# Altium schematic sheet sensors.SchDoc
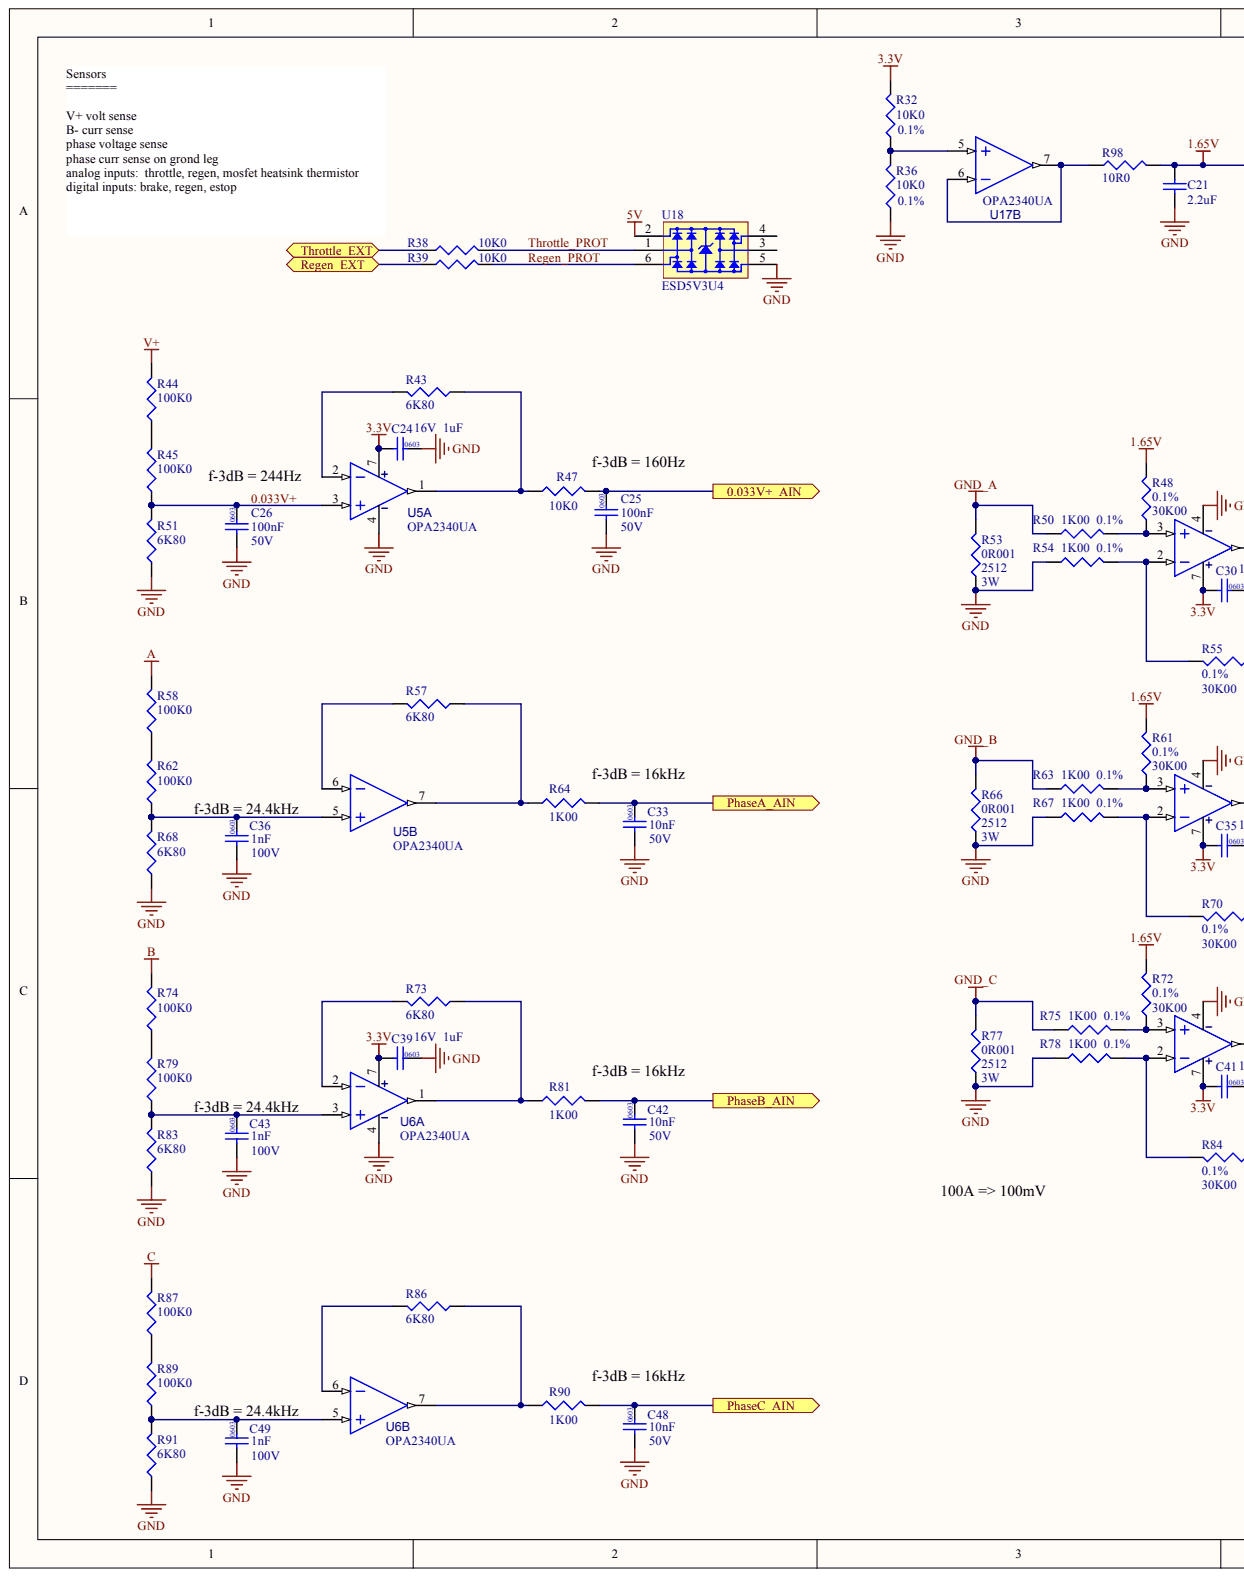
[diagram p0: sensors.SchDoc, left]
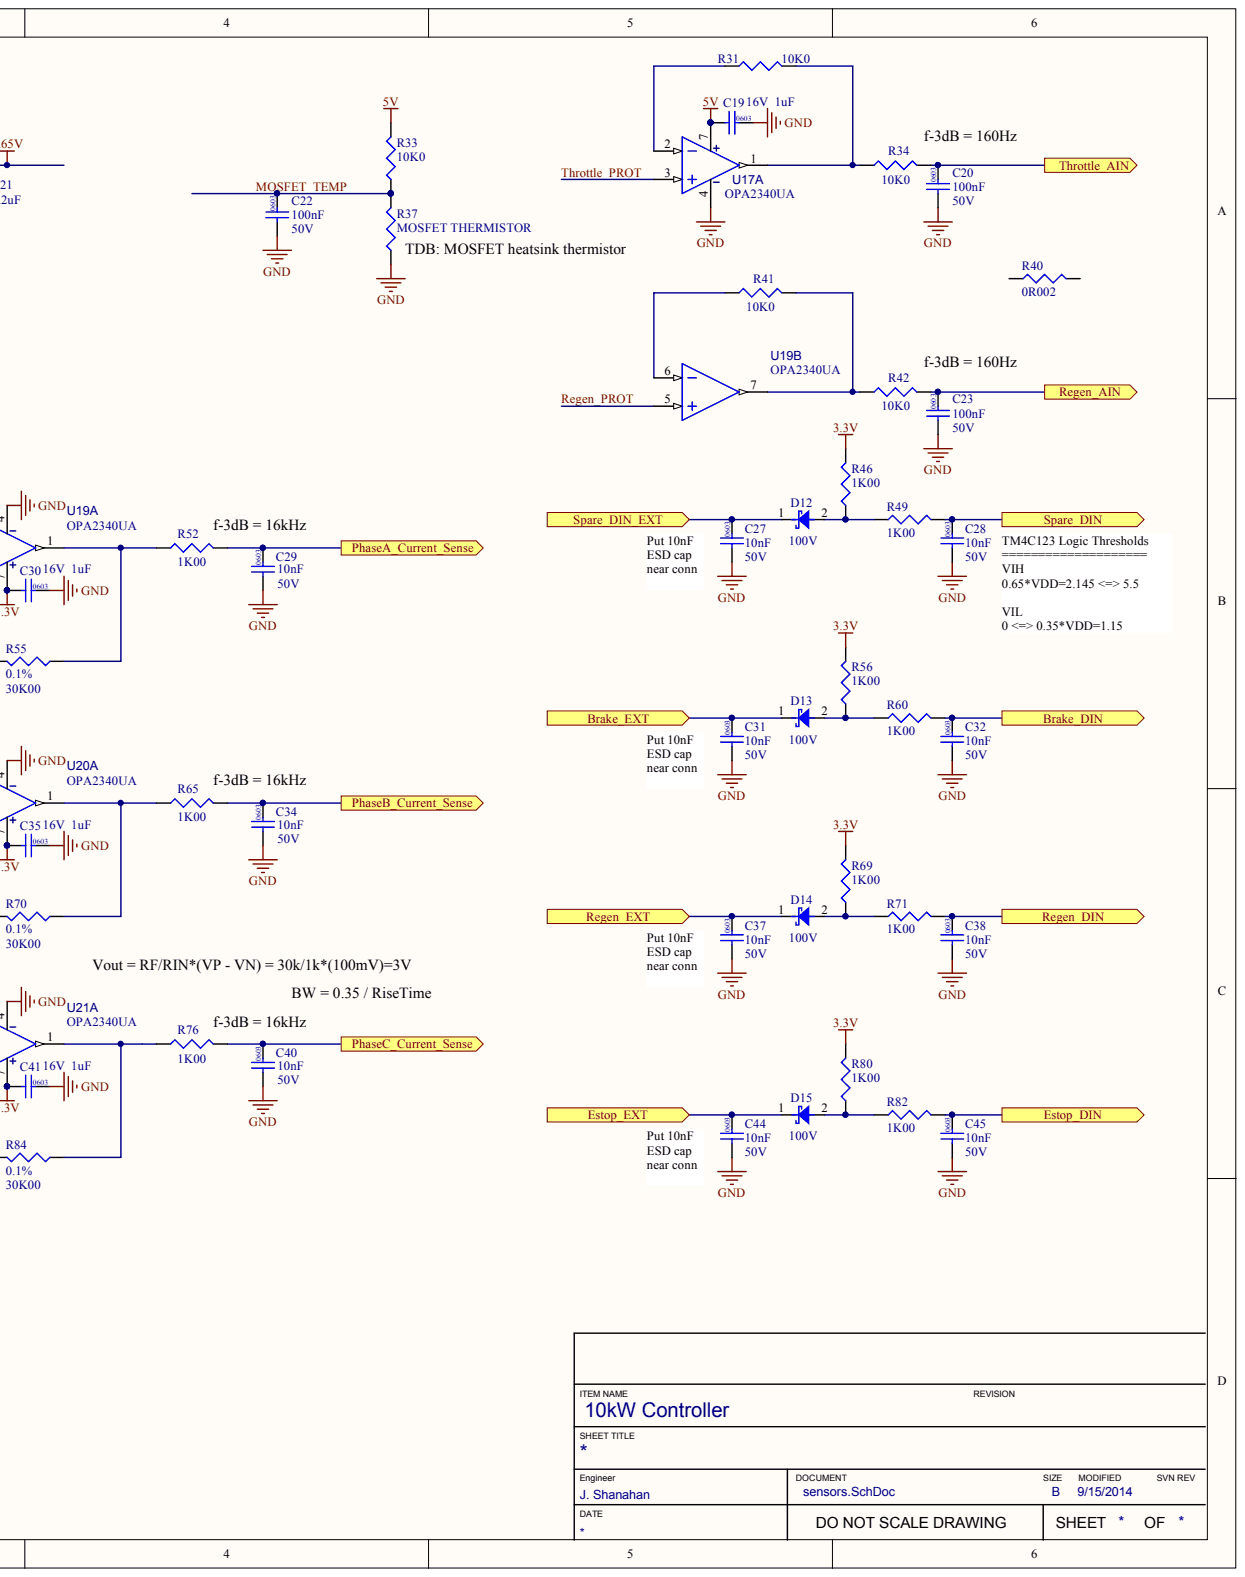
[diagram p1: sensors.SchDoc, right]

## Parts
C19  voltage: 16V  (hidden comment: 1uF)
C20  voltage: 50V  (hidden comment: 100nF)
C21  value 2.2uF  (hidden comment: 2.2UF,10V,X7R(0603))
C22  voltage: 50V  (hidden comment: 100nF)
C23  voltage: 50V  (hidden comment: 100nF)
C24  voltage: 16V  (hidden comment: 1uF)
C25  voltage: 50V  (hidden comment: 100nF)
C26  voltage: 50V  (hidden comment: 100nF)
C27  value 10nF  Voltage: 50V  (hidden comment: 2005187)
C28  Voltage: 50V  (hidden comment: 10nF)
C29  Voltage: 50V  (hidden comment: 10nF)
C30  voltage: 16V  (hidden comment: 1uF)
C31  value 10nF  Voltage: 50V  (hidden comment: 2005187)
C32  Voltage: 50V  (hidden comment: 10nF)
C33  Voltage: 50V  (hidden comment: 10nF)
C34  Voltage: 50V  (hidden comment: 10nF)
C35  voltage: 16V  (hidden comment: 1uF)
C36  Voltage: 100V  (hidden comment: 1nF)
C37  value 10nF  Voltage: 50V  (hidden comment: 2005187)
C38  Voltage: 50V  (hidden comment: 10nF)
C39  voltage: 16V  (hidden comment: 1uF)
C40  Voltage: 50V  (hidden comment: 10nF)
C41  voltage: 16V  (hidden comment: 1uF)
C42  Voltage: 50V  (hidden comment: 10nF)
C43  Voltage: 100V  (hidden comment: 1nF)
C44  value 10nF  Voltage: 50V  (hidden comment: 2005187)
C45  Voltage: 50V  (hidden comment: 10nF)
C48  Voltage: 50V  (hidden comment: 10nF)
C49  Voltage: 100V  (hidden comment: 1nF)
D12  voltage: 100V  (hidden comment: 1046968-00-A)
D13  voltage: 100V  (hidden comment: 1046968-00-A)
D14  voltage: 100V  (hidden comment: 1046968-00-A)
D15  voltage: 100V  (hidden comment: 1046968-00-A)
R31  10K0
R32  value 10K0  Tolerance: 0.1%  (hidden comment: 10K0,0.10W,0.1%(0603))
R33  10K0
R34  10K0
R36  value 10K0  Tolerance: 0.1%  (hidden comment: 10K0,0.10W,0.1%(0603))
R37  MOSFET THERMISTOR
R38  10K0
R39  10K0
R40  value 0R002  (hidden comment: 0R002,1.0W,1%(2512))
R41  10K0
R42  10K0
R43  value 6K80  (hidden comment: CRCW06036K80FKTA)
R44  100K0
R45  100K0
R46  1K00
R47  10K0
R48  value 30K00  Tolerance: 0.1%  (hidden comment: RG1608P-303-B-T5)
R49  value 1K00  (hidden comment: 2006259)
R50  value 1K00  Tolerance: 0.1%  (hidden comment: TNPW06031K00BEEA)
R51  value 6K80  (hidden comment: CRCW06036K80FKTA)
R52  1K00
R53  value 0R001  Package: 2512  Power: 3W  (hidden comment: 0R001,1.0W,1%(2512))
R54  value 1K00  Tolerance: 0.1%  (hidden comment: TNPW06031K00BEEA)
R55  value 30K00  Tolerance: 0.1%  (hidden comment: RG1608P-303-B-T5)
R56  1K00
R57  value 6K80  (hidden comment: CRCW06036K80FKTA)
R58  100K0
R60  value 1K00  (hidden comment: 2006259)
R61  value 30K00  Tolerance: 0.1%  (hidden comment: RG1608P-303-B-T5)
R62  100K0
R63  value 1K00  Tolerance: 0.1%  (hidden comment: TNPW06031K00BEEA)
R64  1K00
R65  1K00
R66  value 0R001  Package: 2512  Power: 3W  (hidden comment: 0R001,1.0W,1%(2512))
R67  value 1K00  Tolerance: 0.1%  (hidden comment: TNPW06031K00BEEA)
R68  value 6K80  (hidden comment: CRCW06036K80FKTA)
R69  1K00
R70  value 30K00  Tolerance: 0.1%  (hidden comment: RG1608P-303-B-T5)
R71  value 1K00  (hidden comment: 2006259)
R72  value 30K00  Tolerance: 0.1%  (hidden comment: RG1608P-303-B-T5)
R73  value 6K80  (hidden comment: CRCW06036K80FKTA)
R74  100K0
R75  value 1K00  Tolerance: 0.1%  (hidden comment: TNPW06031K00BEEA)
R76  1K00
R77  value 0R001  Package: 2512  Power: 3W  (hidden comment: 0R001,1.0W,1%(2512))
R78  value 1K00  Tolerance: 0.1%  (hidden comment: TNPW06031K00BEEA)
R79  100K0
R80  1K00
R81  1K00
R82  value 1K00  (hidden comment: 2006259)
R83  value 6K80  (hidden comment: CRCW06036K80FKTA)
R84  value 30K00  Tolerance: 0.1%  (hidden comment: RG1608P-303-B-T5)
R86  value 6K80  (hidden comment: CRCW06036K80FKTA)
R87  100K0
R89  100K0
R90  1K00
R91  value 6K80  (hidden comment: CRCW06036K80FKTA)
R98  value 10R0  (hidden comment: CRCW060310R0FKEA)
U5  OPA2340UA  (parts A,B)
U6  OPA2340UA  (parts A,B)
U17  OPA2340UA  (parts A,B)
U18  ESD5V3U4
U19  OPA2340UA  (parts A,B)
U20  OPA2340UA  (part A)
U21  OPA2340UA  (part A)

## Ports
0.033V+_AIN, BRAKE_DIN, BRAKE_EXT, ESTOP_DIN, ESTOP_EXT, PHASEA_AIN, PHASEA_CURRENT_SENSE, PHASEB_AIN, PHASEB_CURRENT_SENSE, PHASEC_AIN, PHASEC_CURRENT_SENSE, REGEN_AIN, REGEN_DIN, REGEN_EXT, SPARE_DIN, SPARE_DIN_EXT, THROTTLE_AIN, THROTTLE_EXT

## Nets
1.65V: C21.2 R48.2 R61.2 R72.2 R98.2
3.3V: C24.2 C30.2 C35.2 C39.2 C41.2 R32.2 R46.2 R56.2 R69.2 R80.2 U5.7 U6.7 U19.7 U20.7 U21.7
5V: C19.2 R33.2 U17.7 U18.2
A: R58.2
B: R74.2
C: R87.2
GND: C19.1 C20.2 C21.1 C22.2 C23.2 C24.1 C25.2 C26.2 C27.2 C28.2 C29.2 C30.1 C31.2 C32.2 C33.2 C34.2 C35.1 C36.2 C37.2 C38.2 C39.1 C40.2 C41.1 C42.2 C43.2 C44.2 C45.2 C48.2 C49.2 R36.1 R37.1 R51.1 R53.1 R54.1 R66.1 R67.1 R68.1 R77.1 R78.1 R83.1 R91.1 U5.4 U6.4 U17.4 U18.5 U19.4 U20.4 U21.4
GND_A: R50.1 R53.2
GND_B: R63.1 R66.2
GND_C: R75.1 R77.2
V+: R44.2
0.033V+: C26.1 R45.1 R51.2 U5.3
0.033V+_AIN: C25.1 R47.2 ; port 0.033V+_AIN
MOSFET_TEMP: C22.1 R33.1 R37.2
REGEN_PROT: R39.2 U18.6 U19.5
THROTTLE_PROT: R38.2 U17.3 U18.1
NetC20_1: C20.1 R34.2 ; port THROTTLE_AIN
NetC23_1: C23.1 R42.2 ; port REGEN_AIN
NetC27_1: C27.1 D12.1 ; port SPARE_DIN_EXT
NetC28_1: C28.1 R49.2 ; port SPARE_DIN
NetC29_1: C29.1 R52.2 ; port PHASEA_CURRENT_SENSE
NetC31_1: C31.1 D13.1 ; port BRAKE_EXT
NetC32_1: C32.1 R60.2 ; port BRAKE_DIN
NetC33_1: C33.1 R64.2 ; port PHASEA_AIN
NetC34_1: C34.1 R65.2 ; port PHASEB_CURRENT_SENSE
NetC36_1: C36.1 R62.1 R68.2 U5.5
NetC37_1: C37.1 D14.1 R39.1 ; port REGEN_EXT
NetC38_1: C38.1 R71.2 ; port REGEN_DIN
NetC40_1: C40.1 R76.2 ; port PHASEC_CURRENT_SENSE
NetC42_1: C42.1 R81.2 ; port PHASEB_AIN
NetC43_1: C43.1 R79.1 R83.2 U6.3
NetC44_1: C44.1 D15.1 ; port ESTOP_EXT
NetC45_1: C45.1 R82.2 ; port ESTOP_DIN
NetC48_1: C48.1 R90.2 ; port PHASEC_AIN
NetC49_1: C49.1 R89.1 R91.2 U6.5
NetD12_2: D12.2 R46.1 R49.1
NetD13_2: D13.2 R56.1 R60.1
NetD14_2: D14.2 R69.1 R71.1
NetD15_2: D15.2 R80.1 R82.1
NetR31_1: R31.1 U17.2
NetR31_2: R31.2 R34.1 U17.1
NetR32_1: R32.1 R36.2 U17.5
NetR38_1: R38.1 ; port THROTTLE_EXT
NetR41_1: R41.1 U19.6
NetR41_2: R41.2 R42.1 U19.7
NetR43_1: R43.1 R47.1 U5.1
NetR43_2: R43.2 U5.2
NetR44_1: R44.1 R45.2
NetR48_1: R48.1 R50.2 U19.3
NetR52_1: R52.1 R55.1 U19.1
NetR54_2: R54.2 R55.2 U19.2
NetR57_1: R57.1 R64.1 U5.7
NetR57_2: R57.2 U5.6
NetR58_1: R58.1 R62.2
NetR61_1: R61.1 R63.2 U20.3
NetR65_1: R65.1 R70.1 U20.1
NetR67_2: R67.2 R70.2 U20.2
NetR72_1: R72.1 R75.2 U21.3
NetR73_1: R73.1 R81.1 U6.1
NetR73_2: R73.2 U6.2
NetR74_1: R74.1 R79.2
NetR76_1: R76.1 R84.1 U21.1
NetR78_2: R78.2 R84.2 U21.2
NetR86_1: R86.1 R90.1 U6.7
NetR86_2: R86.2 U6.6
NetR87_1: R87.1 R89.2
NetR98_1: R98.1 U17.6 U17.7

Not connected: R40 1 2; U18 3 4

## Library data of the parts
C19 C24 C30 C35 C39 C41 = (no symbol name): tolerance: 10%; MFG1_PN: GCM188R71C105KA64D; MFG1: Murata; Load: NL; Detail Description: 1uF Capacitor, 16V, X7R, 10%, in 0603 package, Automotive Grade.; TPN: 01-006170-00; Enovia: 2005400; SAP: 2005400; Value: 1uF
C20 C22 C23 C25 C26 = (no symbol name): MFG1_PN: GCM188R71H104KA57D; MFG1: Murata; Value: 100nF
C21 = (no symbol name): tolerance: 10%; TPN: 01-004842-00; LEVEL: 1; MFG1: MURATA; MFG1_PN: GRM188R71A225KE15D; SAP: 2007798; Revision: July-2002: Re-released for DXP Platform.; voltage: 10V; RoHS: YES; TYPE: X7R; Supplier 1: Digi-Key; Supplier 2: Digi-Key; Supplier Part Number 1: 490-4520-6-ND; Supplier Part Number 2: 490-4520-2-ND
C27 C29 C31 C33 C34 C37 C40 C42 C44 C48 = (no symbol name): Tolerance: 10%; MFG1_PN: GCM188R71H103KA37D; MFG1: Murata; Load: NL; Detail Description: 10nF Capacitor, 50V, X7R, 10%, in 0603 package, Automotive Grade.; TPN: 01-006036-00
  C27 C31 C37 C44: Enovia: 2005187; SAP: 2005187
  C29 C33 C34 C40 C42 C48: Value: 10nF
C28 C32 C38 C45 = (no symbol name): Tolerance: 10%; MFG1_PN: GCM188R71H103KA37D; MFG1: Murata; Load: NL; Detail Description: 10nF Capacitor, 50V, X7R, 10%, in 0603 package, Automotive Grade.; TPN: 01-006036-00; Enovia: 2005187; Value: 10nF; SAP: 2005187
C36 C43 C49 = (no symbol name): Tolerance: 10%; MFG1_PN: GCM188R72A102KA37D; MFG1: Murata; Load: NL; Detail Description: 1nF Capacitor, 100V, X7R, 10%, in 0603 package, Automotive Grade.; TPN: 01-006062-00; Value: 1nF
D12-D15 = (no symbol name): MFG1: NXP; Load: NL; MFG1_PN: BAT46WJ,115; Local: BAT46WJ; SAP: 1046968-00-A; Automotive: Yes
R31 R33 R34 R37-R39 R41 R42 R47 = (no symbol name): Tolerance: 1%; POWER: 0.10W; MFG1_PN: CRCW060310K0FKEA; MFG1: Vishay; Load: NL; Detail Description: Resistor, 10K0, 0603 package, automotive grade.; TPN: 01-007331-00; Enovia: 2006240; Revision: July-2002: Re-released for DXP Platform.; RoHS: Yes; SAP: 2006240
  R31 R33 R34 R38 R39 R41 R42 R47: Value: 10K0
  R37: Value: MOSFET THERMISTOR
R32 R36 = (no symbol name): LEVEL: 1; MFG1_PN: TNPW060310K0BEEA; MFG1: VISHAY/DALE; Revision: July-2002: Re-released for DXP Platform.; RoHS: Yes; POWER: 0.10W; Automotive: YES
R40 = (no symbol name): TPN: 01-006570-00; Rating: 0.1W; MFG1_PN: ERJ-M1WTJ2M0U; MFG1: PANASONIC; SAP: 2005720; Published: 8-Jun-2000; Revision: July-2002: Re-released for DXP Platform.; Tolerance: 1%; RoHS: YES; Supplier 1: Digi-Key; Supplier 2: Digi-Key; Supplier Part Number 1: P2.0TDKR-ND; Supplier Part Number 2: P2.0TTR-ND
R43 R51 R57 R68 R73 R83 R86 R91 = (no symbol name): Tolerance: 1%; TPN: 01-000031-00; POWER: 0.10W; LEVEL: 1; MFG1: VISHAY; SAP: 1001364-00-A; Revision: July-2002: Re-released for DXP Platform.; RoHS: YES; Automotive: YES; MFG1_PN: CRCW06036K80FKTA
R44 R45 R58 R62 R74 R79 R87 R89 = (no symbol name): Tolerance: 1%; TPN: 01-007338-00; POWER: 0.10W; MFG1_PN: CRCW0603100KFKEA; MFG1: Vishay; Load: NL; Detail Description: Resistor, 100K, 0603 package, automotive grade.; Enovia: 2006243; TESLA_LIB: 13-JUL-06; SAP: 2006243; Value: 100K0
R46 R52 R56 R64 R65 R69 R76 R80 R81 R90 = (no symbol name): Tolerance: 1%; POWER: 0.10W; MFG1_PN: CRCW06031K00FKEA; MFG1: Vishay; Revision: July-2002: Re-released for DXP Platform.; Value: 1K00
R48 R55 R61 R70 R72 R84 = (no symbol name): POWER: 0.10W; MFG1: SUSUMU; TPN: 01-002090-00; LEVEL: 1; MFG2_PN: ERA-3AEB303V; SAP: 2000458; Revision: July-2002: Re-released for DXP Platform.; MFG1_PN: RG1608P-303-B-T5; RoHS: YES; MFG2: PANASONIC; Supplier 1: Digi-Key; Supplier 2: Digi-Key; Supplier 3: Digi-Key; Supplier 4: Digi-Key; Supplier Part Number 1: RG16P30.0KBTR-ND; Supplier Part Number 2: RG16P30.0KBDKR-ND; Supplier Part Number 3: P30KDBTR-ND; Supplier Part Number 4: P30KDBDKR-ND
R49 R60 R71 R82 = (no symbol name): Tolerance: 1%; POWER: 0.10W; MFG1_PN: CRCW06031K00FKEA; MFG1: Vishay; Load: NL; Detail Description: Resistor, 1K, 0603 package, automotive grade.; TPN: 01-007330-00; Revision: July-2002: Re-released for DXP Platform.; RoHS: YES; Enovia: 2006259; SAP: 2006259
R50 R54 R63 R67 R75 R78 = (no symbol name): POWER: 0.10W; MFG1: VISHAY; LEVEL: 1; SAP: 1003747-00-A; Revision: July-2002: Re-released for DXP Platform.; MFG1_PN: TNPW06031K00BEEA; RoHS: YES; Automotive: YES
R53 R66 R77 = (no symbol name): MFGPN: CSR2512C0R001F; MFG: Riedon; Revision: July-2002: Re-released for DXP Platform.; Unit Price: 0.67
R98 = (no symbol name): Tolerance: 1%; TPN: 01-007341-00; POWER: 0.10W; LEVEL: 1; MFG1: VISHAY/DALE; SAP: 2006236; Revision: July-2002: Re-released for DXP Platform.; RoHS: YES; MFG1_PN: CRCW060310R0FKEA; Automotive: YES; Supplier 1: Digi-Key; Supplier 2: Digi-Key; Supplier Part Number 1: 541-10.0HTR-ND; Supplier Part Number 2: 541-10.0HDKR-ND
U5 U6 U17 U19-U21 = (no symbol name): MFG1: TI; MFG1_PN: OPA2340UA
U18 = (no symbol name): MFG1: Infineon; MFG1_PN: ESD5V3U4RRSH6327XTSA1; Local_Name: ESD5V3U4RRS; Load: NL; Detail Description: TVS array for ESD protection in SC-70-6 package, not automotive grade.; TPN: 01-008801-00; Enovia: 2008067; RoHS: YES
